# Altium schematic sheet 2模块1.SchDoc(2模块1)
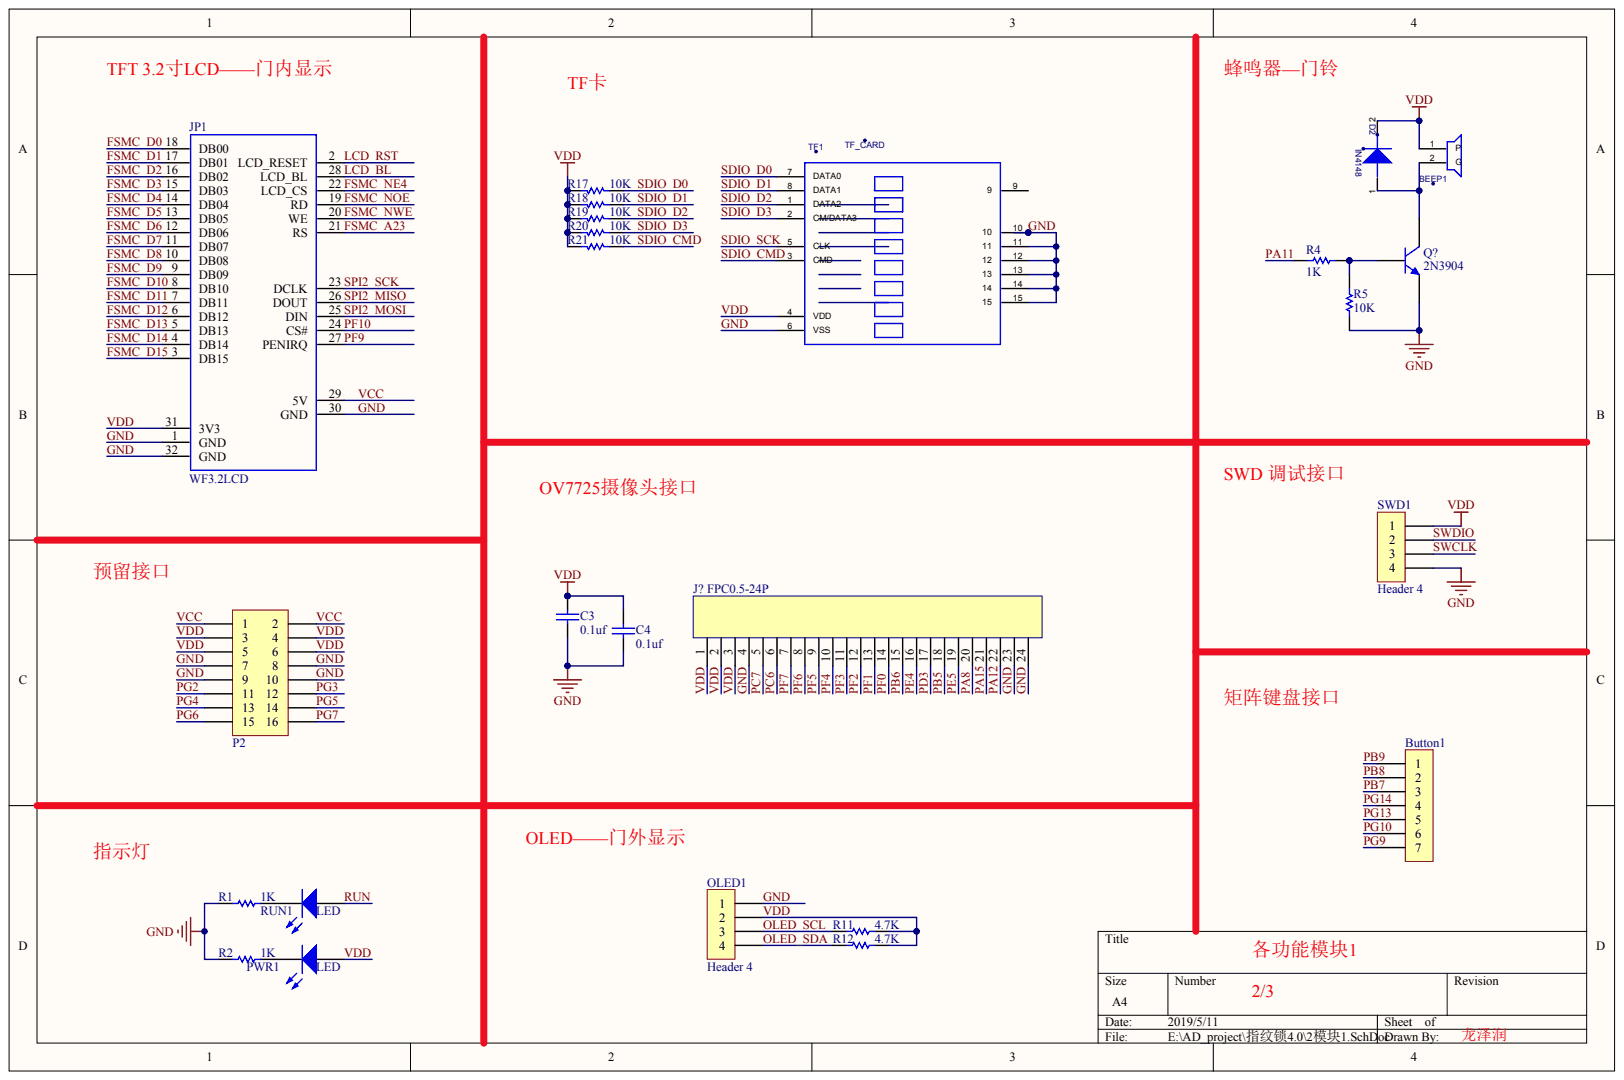
[diagram p0: 2模块1.SchDoc]

## Parts
BEEP1  BEEP-9.5X5.5  (value not drawn)
Button1  Pin HDR1X7  (value not drawn)
C3  值: 0.1uf  (hidden comment: Cap 1)
C4  值: 0.1uf  (hidden comment: Cap 1)
D2  IN4148
J?  FPC0.5-24P
JP1  WF3.2LCD
OLED1  Header 4
P2  Header 2*8  (value not drawn)
PWR1  LED
Q?  2N3904
R1  值: 1K  (hidden comment: Res 1)
R2  值: 1K  (hidden comment: Res 1)
R4  值: 1K  (hidden comment: Res 1)
R5  值: 10K  (hidden comment: Res 1)
R11  值: 4.7K  (hidden comment: Res 1)
R12  值: 4.7K  (hidden comment: Res 1)
R17  值: 10K  (hidden comment: Res 1)
R18  值: 10K  (hidden comment: Res 1)
R19  值: 10K  (hidden comment: Res 1)
R20  值: 10K  (hidden comment: Res 1)
R21  值: 10K  (hidden comment: Res 1)
RUN1  LED
SWD1  Header 4
TF1  TF_CARD

## Nets
FSMC_A23: JP1.21(RS)
FSMC_D0: JP1.18(DB00)
FSMC_D1: JP1.17(DB01)
FSMC_D2: JP1.16(DB02)
FSMC_D3: JP1.15(DB03)
FSMC_D4: JP1.14(DB04)
FSMC_D5: JP1.13(DB05)
FSMC_D6: JP1.12(DB06)
FSMC_D7: JP1.11(DB07)
FSMC_D8: JP1.10(DB08)
FSMC_D9: JP1.9(DB09)
FSMC_D10: JP1.8(DB10)
FSMC_D11: JP1.7(DB11)
FSMC_D12: JP1.6(DB12)
FSMC_D13: JP1.5(DB13)
FSMC_D14: JP1.4(DB14)
FSMC_D15: JP1.3(DB15)
FSMC_NE4: JP1.22(LCD_CS)
FSMC_NOE: JP1.19(RD)
FSMC_NWE: JP1.20(WE)
GND: C3.2 C4.2 J?.4 J?.23 J?.24 JP1.1(GND) JP1.30(GND) JP1.32(GND) OLED1.1 P2.7 P2.8 P2.9 P2.10 Q?.1 R1.2 R2.2 R5.1 SWD1.4 TF1.6(VSS) TF1.10 TF1.11 TF1.12 TF1.13 TF1.14 TF1.15
LCD_BL: JP1.28(LCD_BL)
LCD_RST: JP1.2(LCD_RESET)
OLED_SCL: OLED1.3 R11.2
OLED_SDA: OLED1.4 R12.2
PA8: J?.20
PA11: R4.2
PA12: J?.22
PA15: J?.21
PB5: J?.18
PB6: J?.15
PB7: Button1.3
PB8: Button1.2
PB9: Button1.1
PC6: J?.6
PC7: J?.5
PD3: J?.17
PE4: J?.16
PE5: J?.19
PF0: J?.14
PF1: J?.13
PF2: J?.12
PF3: J?.11
PF4: J?.10
PF5: J?.9
PF6: J?.8
PF7: J?.7
PF9: JP1.27(PENIRQ)
PF10: JP1.24(CS#)
PG2: P2.11
PG3: P2.12
PG4: P2.13
PG5: P2.14
PG6: P2.15
PG7: P2.16
PG9: Button1.7
PG10: Button1.6
PG13: Button1.5
PG14: Button1.4
RUN: RUN1.1
SDIO_CMD: R21.2 TF1.3(CMD)
SDIO_D0: R17.2 TF1.7(DATA0)
SDIO_D1: R18.2 TF1.8(DATA1)
SDIO_D2: R19.2 TF1.1(DATA2)
SDIO_D3: R20.2 TF1.2(CM/DATA3)
SDIO_SCK: TF1.5(CLK)
SPI2_MISO: JP1.26(DOUT)
SPI2_MOSI: JP1.25(DIN)
SPI2_SCK: JP1.23(DCLK)
SWCLK: SWD1.3
SWDIO: SWD1.2
VCC: JP1.29(5V) P2.1 P2.2
VDD: BEEP1.1 C3.1 C4.1 D2.2 J?.1 J?.2 J?.3 JP1.31(3V3) OLED1.2 P2.3 P2.4 P2.5 P2.6 PWR1.1 R11.1 R12.1 R17.1 R18.1 R19.1 R20.1 R21.1 SWD1.1 TF1.4(VDD)
NetBEEP1_2: BEEP1.2 D2.1 Q?.3
NetPWR1_2: PWR1.2 R2.1
NetQ?_2: Q?.2 R4.1 R5.2
NetR1_1: R1.1 RUN1.2
N00146:
N00147:
N00148:
N00149:
N00150:
N00151:
N00152:
N00153:

Not connected: TF1 9

## Library data of the parts
D2 = (no symbol name): PCB DECAL: DIODE_SOP; VALUE: IN4148
PWR1 RUN1 = (no symbol name): 值: GRN
BEEP1 Button1 C3 C4 J? JP1 OLED1 P2 Q? R1 R2 R4 R5 R11 R12 R17-R21 SWD1 TF1 = (no symbol name)
  BEEP1: PCB DECAL: BEEP-9.5X5.5
  JP1: PCB DECAL: *
  OLED1 SWD1: LatestRevisionDate: 17-Jul-2002; LatestRevisionNote: Re-released for DXP Platform.
  Q?: Published: 8-Jun-2000; LatestRevisionDate: 15-Jan-2003; LatestRevisionNote: PCB Footprint 'BCY-W3/D4.7' replaced; DatasheetVersion: 1997; PackageVersion: Sep-1998; PackageReference: TO-92A; PackageDescription: TO, Flat Index; 3 In-Line, Axial Leads; Body Dia. 4.5mm; Leads 0.51 x 0.48 mm (max); ComponentLink1Description: Manufacturer Link; ComponentLink1URL: http://www.fairchildsemi.com/; ComponentLink2Description: Datasheet; ComponentLink2URL: http://www.fairchildsemi.com/ds/2N%2F2N3904.pdf
  TF1: PCB DECAL: TF_CARD
  OLED1 Q? SWD1: Publisher: Altium Limited
